# Altium schematic sheet control.SchDoc(control)
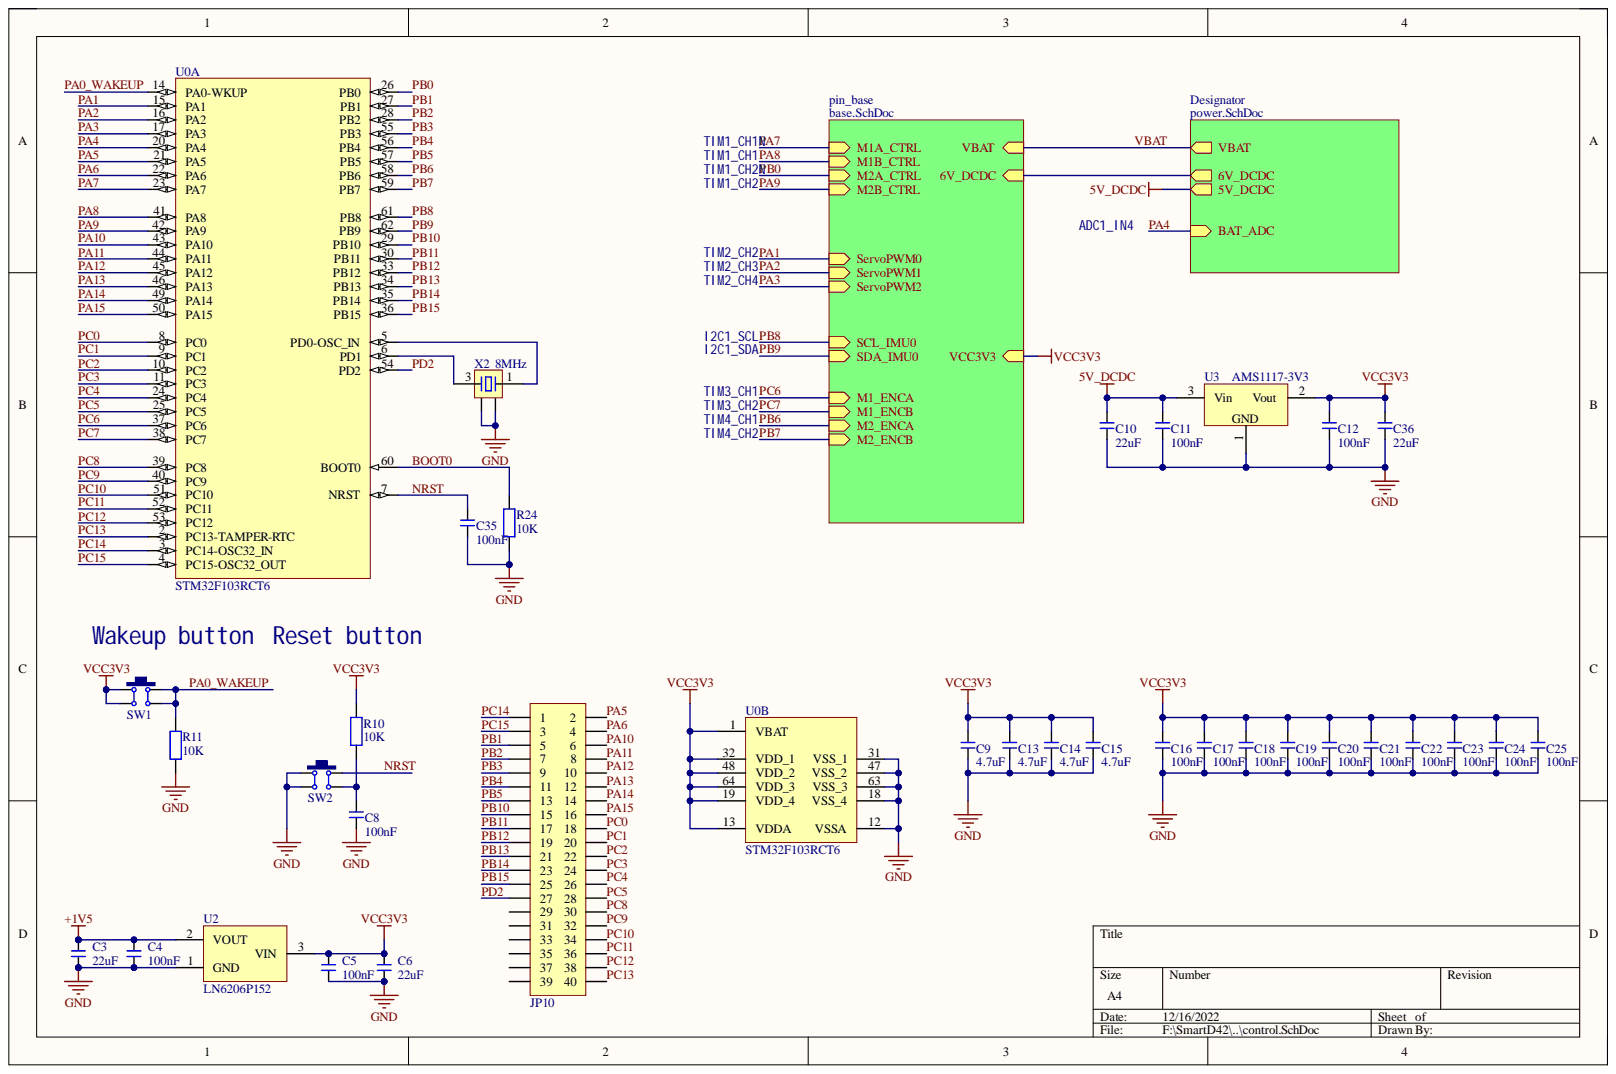
[diagram p0: control.SchDoc]

## Parts
C3  22uF  [C 0603_M]
C4  100nF  [C 0402_M]
C5  100nF  [C 0402_M]
C6  22uF  [C 0603_M]
C8  100nF  [C 0402_M]
C9  4.7uF  [C 0603_M]
C10  22uF  [C 1206_M]
C11  100nF  [C 0603_M]
C12  100nF  [C 0603_M]
C13  4.7uF  [C 0603_M]
C14  4.7uF  [C 0603_M]
C15  4.7uF  [C 0603_M]
C16  100nF  [C 0402_M]
C17  100nF  [C 0402_M]
C18  100nF  [C 0402_M]
C19  100nF  [C 0402_M]
C20  100nF  [C 0402_M]
C21  100nF  [C 0402_M]
C22  100nF  [C 0402_M]
C23  100nF  [C 0402_M]
C24  100nF  [C 0402_M]
C25  100nF  [C 0402_M]
C35  100nF  [C 0603_M]
C36  22uF  [C 1206_M]
JP10  Header 2X11  [HDR2.54-LI-2x20P]  (value not drawn)
R10  10K  [R 0402_M]
R11  10K  [R 0402_M]
R24  10K  [R 0402_M]
SW1  6x6x5  [TSW SMD-6*6*4.3]  (value not drawn)
SW2  6x6x5  [TSW SMD-6*6*4.3]  (value not drawn)
U0  STM32F103RCT6  [LQFP64_N]  (parts A,B)
U2  LN6206P152  [SOT95P280X125-3M]
U3  AMS1117-3V3  [SOT223_L]
X2  8MHz  [OSC 1612-4P]

## Nets
5V_DCDC: C10.2 C11.2 U3.3
+1V5: C3.2 C4.2 U2.2
GND: C3.1 C4.1 C5.1 C6.1 C8.1 C9.1 C10.1 C11.1 C12.1 C13.1 C14.1 C15.1 C16.1 C17.1 C18.1 C19.1 C20.1 C21.1 C22.1 C23.1 C24.1 C25.1 C35.1 C36.1 R11.1 R24.1 SW2.1 SW2.4 U0.12(VSSA) U0.18(VSS_4) U0.31(VSS_1) U0.47(VSS_2) U0.63(VSS_3) U2.1 U3.1 X2.2 X2.4
VCC3V3: C5.2 C6.2 C9.2 C12.2 C13.2 C14.2 C15.2 C16.2 C17.2 C18.2 C19.2 C20.2 C21.2 C22.2 C23.2 C24.2 C25.2 C36.2 R10.2 SW1.1 SW1.4 U0.1(VBAT) U0.13(VDDA) U0.19(VDD_4) U0.32(VDD_1) U0.48(VDD_2) U0.64(VDD_3) U2.3 U3.2
BOOT0: R24.2 U0.60(BOOT0)
NRST: C35.2 SW2.2 U0.7(NRST)
PA0_WAKEUP: R11.2 SW1.2 SW1.3 U0.14(PA0-WKUP)
PA1: U0.15(PA1)
PA2: U0.16(PA2)
PA3: U0.17(PA3)
PA4: U0.20(PA4)
PA5: JP10.2 U0.21(PA5)
PA6: JP10.4 U0.22(PA6)
PA7: U0.23(PA7)
PA8: U0.41(PA8)
PA9: U0.42(PA9)
PA10: JP10.6 U0.43(PA10)
PA11: JP10.8 U0.44(PA11)
PA12: JP10.10 U0.45(PA12)
PA13: JP10.12 U0.46(PA13)
PA14: JP10.14 U0.49(PA14)
PA15: JP10.16 U0.50(PA15)
PB0: U0.26(PB0)
PB1: JP10.5 U0.27(PB1)
PB2: JP10.7 U0.28(PB2)
PB3: JP10.9 U0.55(PB3)
PB4: JP10.11 U0.56(PB4)
PB5: JP10.13 U0.57(PB5)
PB6: U0.58(PB6)
PB7: U0.59(PB7)
PB8: U0.61(PB8)
PB9: U0.62(PB9)
PB10: JP10.15 U0.29(PB10)
PB11: JP10.17 U0.30(PB11)
PB12: JP10.19 U0.33(PB12)
PB13: JP10.21 U0.34(PB13)
PB14: JP10.23 U0.35(PB14)
PB15: JP10.25 U0.36(PB15)
PC0: JP10.18 U0.8(PC0)
PC1: JP10.20 U0.9(PC1)
PC2: JP10.22 U0.10(PC2)
PC3: JP10.24 U0.11(PC3)
PC4: JP10.26 U0.24(PC4)
PC5: JP10.28 U0.25(PC5)
PC6: U0.37(PC6)
PC7: U0.38(PC7)
PC8: JP10.30 U0.39(PC8)
PC9: JP10.32 U0.40(PC9)
PC10: JP10.34 U0.51(PC10)
PC11: JP10.36 U0.52(PC11)
PC12: JP10.38 U0.53(PC12)
PC13: JP10.40 U0.2(PC13-TAMPER-RTC)
PC14: JP10.1 U0.3(PC14-OSC32_IN)
PC15: JP10.3 U0.4(PC15-OSC32_OUT)
PD2: JP10.27 U0.54(PD2)
NetC8_2: C8.2 R10.1 SW2.3
NetJP10_29: JP10.29
NetJP10_31: JP10.31
NetJP10_33: JP10.33
NetJP10_35: JP10.35
NetJP10_37: JP10.37
NetJP10_39: JP10.39
NetU0_5: U0.5(PD0-OSC_IN) X2.1
NetU0_6: U0.6(PD1) X2.3
6V_DCDC:
VBAT:

## Library data of the parts
C3-C6 C8-C25 C35 C36 = C: 贴片电容; Component Kind: Standard; Library Name: RLC.SchLib
JP10 = Header 20X2: 20*2P接插件; Component Kind: Standard; Library Name: header.SchLib
R10 R11 R24 = R: 贴片电阻; Component Kind: Standard; Library Name: RLC.SchLib
SW1 SW2 = TSW SMD-6x6: 贴片6x6轻触开关; Component Kind: Standard; Library Name: Schlib.SchLib
U0 = STM32F103RCT6: STM32 ARM-based 32-bit MCU Performance Line with 256 kB Flash, 48 kB Internal RAM, -40 to +85癈 Temperature, 64-Pin LQFP, Tray; Component Kind: Standard; ComponentLink1Description: Manufacturer URL; ComponentLink1URL: http://www.st.com/; ComponentLink2Description: STM32F103 Datasheet; ComponentLink2URL: http://www.st.com/internet/com/TECHNICAL_RESOURCES/TECHNICAL_LITERATURE/DATASHEET/CD00191185.pdf; ComponentLink3Description: STM32 Family Web Page; ComponentLink3URL: www.st.com/stm32; DatasheetVersion: rev8.0, Apr-2011; Library Name: Schlib.SchLib; PackageDescription: 64-Pin Low-Profile Quad Flat Pack, 10 x 10 mm Body, 0.5 mm Pitch (LQFP64); PackageReference: LQFP64; PackageVersion: rev13, Apr-2011
U2 = LN6206: 正 Vin=6V Vout=1.5V~1.5V 250mA 40dB@(1kHz); Component Kind: Standard; Library Name: Schlib.SchLib
U3 = AMS1117: 三端稳压芯片; BOM名称: 稳压芯片; 淘宝ID: 封装库来自淘宝 ID：黄晶宝石; Component Kind: Standard; Library Name: Schlib.SchLib; 欢迎光临淘宝店铺: https://shop463601444.taobao.com
X2 = XTAL-4P: 4脚无源晶振; Component Kind: Standard; Library Name: Schlib.SchLib
